# Altium schematic sheet blip_sheet3.SchDoc
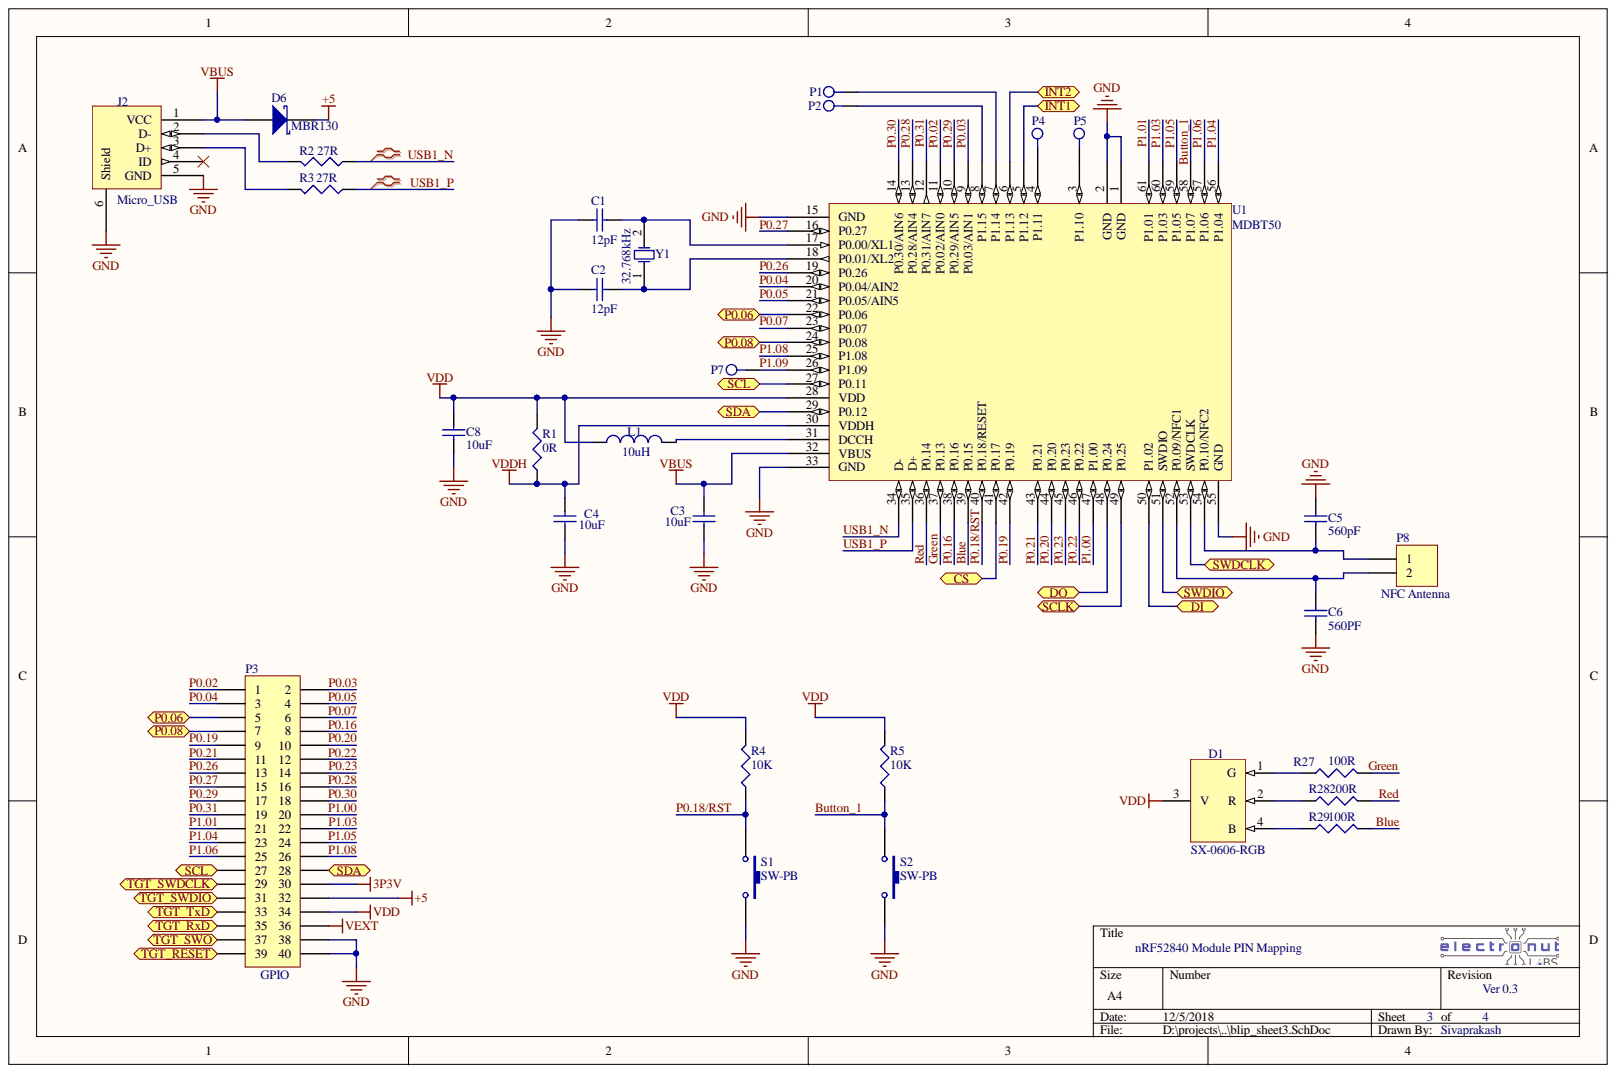
[diagram p0: blip_sheet3.SchDoc]

## Parts
C1  12pF  [1608[0603]]
C2  12pF  [1608[0603]]
C3  10uF  [1608[0603]]
C4  10uF  [1608[0603]]
C5  560pF  [1608[0603]]
C6  560PF  [1608[0603]]
C8  10uF  [1608[0603]]
D1  SX-0606-RGB  [RGB_LED]
D6  MBR130  [SOD_123]
J2  Micro_USB  [Micro_USB]
L1  10uH  [INDC1608L]
P1  P1.14  [TestPoint]  (value not drawn)
P2  P1.15  [TestPoint]  (value not drawn)
P3  GPIO  [2x20_Pitch2.54mm Header]
P4  P1.11  [TestPoint]  (value not drawn)
P5  P1.10  [TestPoint]  (value not drawn)
P7  P1.15  [TestPoint]  (value not drawn)
P8  NFC Antenna  [NFC antenna]
R1  0R  [J1-0603]
R2  27R  [J1-0603]
R3  27R  [J1-0603]
R4  10K  [J1-0603]
R5  10K  [J1-0603]
R27  100R  [J1-0603]
R28  200R  [J1-0603]
R29  100R  [J1-0603]
S1  SW-PB  [Tact_Switch]
S2  SW-PB  [Tact_Switch]
U1  MDBT50  [MDBT50Q]
Y1  32.768kHz  [32.768kHz_crystal]

## Ports
CS, DI, DO, INT1, INT2, P0.06, P0.08, SCL, SCLK, SDA, SWDCLK, SWDIO, TGT_RESET, TGT_RxD, TGT_SWDCLK, TGT_SWDIO, TGT_SWO, TGT_TxD

## Nets
3P3V: P3.30
+5: D6.2 P3.32
GND: C1.1 C2.1 C3.1 C4.1 C5.1 C6.2 C8.1 J2.5(GND) J2.6(Shield) P3.38 P3.40 S1.2 S2.2 U1.1(GND) U1.2(GND) U1.15(GND) U1.33(GND) U1.55(GND)
VBUS: C3.2 D6.1 J2.1(VCC) U1.32(VBUS)
VDD: C8.2 D1.3(V) L1.1 P3.34 R1.2 R4.2 R5.2 U1.28(VDD)
VDDH: C4.2 R1.1 U1.30(VDDH)
VEXT: P3.36
Blue: R29.2 U1.39(P0.15)
Button_1: R5.1 S2.1 U1.58(P1.07)
CS: U1.41(P0.17) ; port CS
DI: U1.50(P1.02) ; port DI
DO: U1.48(P0.24) ; port DO
Green: R27.2 U1.37(P0.13)
INT1: U1.5(P1.12) ; port INT1
INT2: U1.6(P1.13) ; port INT2
P0.02: P3.1 U1.11(P0.02/AIN0)
P0.03: P3.2 U1.9(P0.03/AIN1)
P0.04: P3.3 U1.20(P0.04/AIN2)
P0.05: P3.4 U1.21(P0.05/AIN5)
P0.06: P3.5 U1.22(P0.06) ; port P0.06
P0.07: P3.6 U1.23(P0.07)
P0.08: P3.7 U1.24(P0.08) ; port P0.08
P0.16: P3.8 U1.38(P0.16)
P0.18/RST: R4.1 S1.1 U1.40(P0.18/RESET)
P0.19: P3.9 U1.42(P0.19)
P0.20: P3.10 U1.44(P0.20)
P0.21: P3.11 U1.43(P0.21)
P0.22: P3.12 U1.46(P0.22)
P0.23: P3.14 U1.45(P0.23)
P0.26: P3.13 U1.19(P0.26)
P0.27: P3.15 U1.16(P0.27)
P0.28: P3.16 U1.13(P0.28/AIN4)
P0.29: P3.17 U1.10(P0.29/AIN5)
P0.30: P3.18 U1.14(P0.30/AIN6)
P0.31: P3.19 U1.12(P0.31/AIN7)
P1.00: P3.20 U1.47(P1.00)
P1.01: P3.21 U1.61(P1.01)
P1.03: P3.22 U1.60(P1.03)
P1.04: P3.23 U1.56(P1.04)
P1.05: P3.24 U1.59(P1.05)
P1.06: P3.25 U1.57(P1.06)
P1.08: P3.26 U1.25(P1.08)
P1.09: P7.1 U1.26(P1.09)
Red: R28.2 U1.36(P0.14)
SCL: P3.27 U1.27(P0.11) ; port SCL
SCLK: U1.49(P0.25) ; port SCLK
SDA: P3.28 U1.29(P0.12) ; port SDA
SWDCLK: U1.53(SWDCLK) ; port SWDCLK
SWDIO: U1.51(SWDIO) ; port SWDIO
TGT_RESET: P3.39 ; port TGT_RESET
TGT_RxD: P3.35 ; port TGT_RxD
TGT_SWDCLK: P3.29 ; port TGT_SWDCLK
TGT_SWDIO: P3.31 ; port TGT_SWDIO
TGT_SWO: P3.37 ; port TGT_SWO
TGT_TxD: P3.33 ; port TGT_TxD
USB1_N: R2.1 U1.34(D-)
USB1_P: R3.1 U1.35(D+)
NetC1_2: C1.2 U1.17(P0.00/XL1) Y1.2
NetC2_2: C2.2 U1.18(P0.01/XL2) Y1.1
NetC5_2: C5.2 P8.1 U1.54(P0.10/NFC2)
NetC6_1: C6.1 P8.2 U1.52(P0.09/NFC1)
NetD1_1: D1.1(G) R27.1
NetD1_2: D1.2(R) R28.1
NetD1_4: D1.4(B) R29.1
NetJ2_2: J2.2(D-) R2.2
NetJ2_3: J2.3(D+) R3.2
NetL1_2: L1.2 U1.31(DCCH)
NetP1_1: P1.1 U1.7(P1.14)
NetP2_1: P2.1 U1.8(P1.15)
NetP4_1: P4.1 U1.4(P1.11)
NetP5_1: P5.1 U1.3(P1.10)

Not connected: J2 4(ID)

## Library data of the parts
C1-C6 C8 = Cap Semi: Capacitor (Semiconductor SIM Model); Component Kind: Standard; LatestRevisionDate: 29-May-2009; LatestRevisionNote: IPC-7351 Footprint Added.; Library Name: Miscellaneous Devices.IntLib; PackageDescription: Chip Capacitor; PackageReference: C1206; Published: 8-Jun-2000; Signal Integrity: Cap Semi; Simulation: CAP; Publisher: Altium Limited
D1 = RGB_LED: SMD LED-0603RGB  0606/1615 RGB-Colorful  Common Anode  SIZE:1.6*1.6*0.55MM; Component Kind: Standard; Library Name: blip.SchLib
D6 = D Schottky: Schottky Diode; Code_JEDEC: DO-214-AA; Component Kind: Standard; LatestRevisionDate: 17-Jul-2002; LatestRevisionNote: Re-released for DXP Platform.; Library Name: Miscellaneous Devices.IntLib; PackageDescription: DO-214-AA/SMB; 2 C-Bend Leads; Body 5.3 x 3.6 mm, inc. leads (LxW); PackageReference: SMB; Published: 8-Jun-2000; Signal Integrity: D Schottky; Simulation: SKYDIODE; Publisher: Altium Limited
J2 = Micro_USB: Micro USB 2.0; Component Kind: Standard; Library Name: blip.SchLib
L1 = Inductor: Code_IEC: 1005; Code_JEITA: 0402; Component Kind: Standard; Description: Inductor; LatestRevisionDate: 29-May-2009; LatestRevisionNote: IPC-7351 Footprint Added.; Library Name: Miscellaneous Devices.IntLib; PackageDescription: Chip Inductor; PackageReference: 0402-A; Published: 8-Jun-2000; Signal Integrity: Inductor; Simulation: INDUCTOR; Publisher: Altium Limited
P1 P2 P4 P5 P7 = Test_Point: Component Kind: Standard; Library Name: blip.SchLib
P3 = Header 20X2: Header, 20-Pin, Dual row; Component Kind: Standard; LatestRevisionDate: 17-Jul-2002; LatestRevisionNote: Re-released for DXP Platform.; Library Name: Miscellaneous Connectors.IntLib; Signal Integrity: Connector; Publisher: Altium Limited
P8 = Header 2: Header, 2-Pin; Component Kind: Standard; LatestRevisionDate: 17-Jul-2002; LatestRevisionNote: Re-released for DXP Platform.; Library Name: Miscellaneous Connectors.IntLib; Signal Integrity: Connector; Publisher: Altium Limited
R1-R5 R27-R29 = Res3: Resistor; Component Kind: Standard; LatestRevisionDate: 29-May-2009; LatestRevisionNote: IPC-7351 Footprint Added.; Library Name: Miscellaneous Devices.IntLib; PackageDescription: Chip Resistor; PackageReference: J1-0603; Published: 8-Jun-2000; Signal Integrity: Res; Simulation: RESISTOR; Publisher: Altium Limited
S1 S2 = SW-PB: Switch; Component Kind: Standard; LatestRevisionDate: 17-Jul-2002; LatestRevisionNote: Re-released for DXP Platform.; Library Name: Miscellaneous Devices.IntLib; PackageDescription: Switch; 2 Leads; PackageReference: SPST-2; Published: 24-Mar-1999; Publisher: Altium Limited
U1 = MDBT50Q: nRF52840 Raytac Module; Component Kind: Standard; Library Name: blip.SchLib
Y1 = XTAL: Crystal Oscillator; Component Kind: Standard; LatestRevisionDate: 17-Jul-2002; LatestRevisionNote: Re-released for DXP Platform.; Library Name: Miscellaneous Devices.IntLib; PackageDescription: Crystal, Thru-Hole; 2 Leads; Body 3.1 x 8.2 mm (Dia.xH); PackageReference: R38; Published: 8-Jun-2000; Simulation: XTAL; Publisher: Altium Limited
